# Altium schematic sheet main.SchDoc
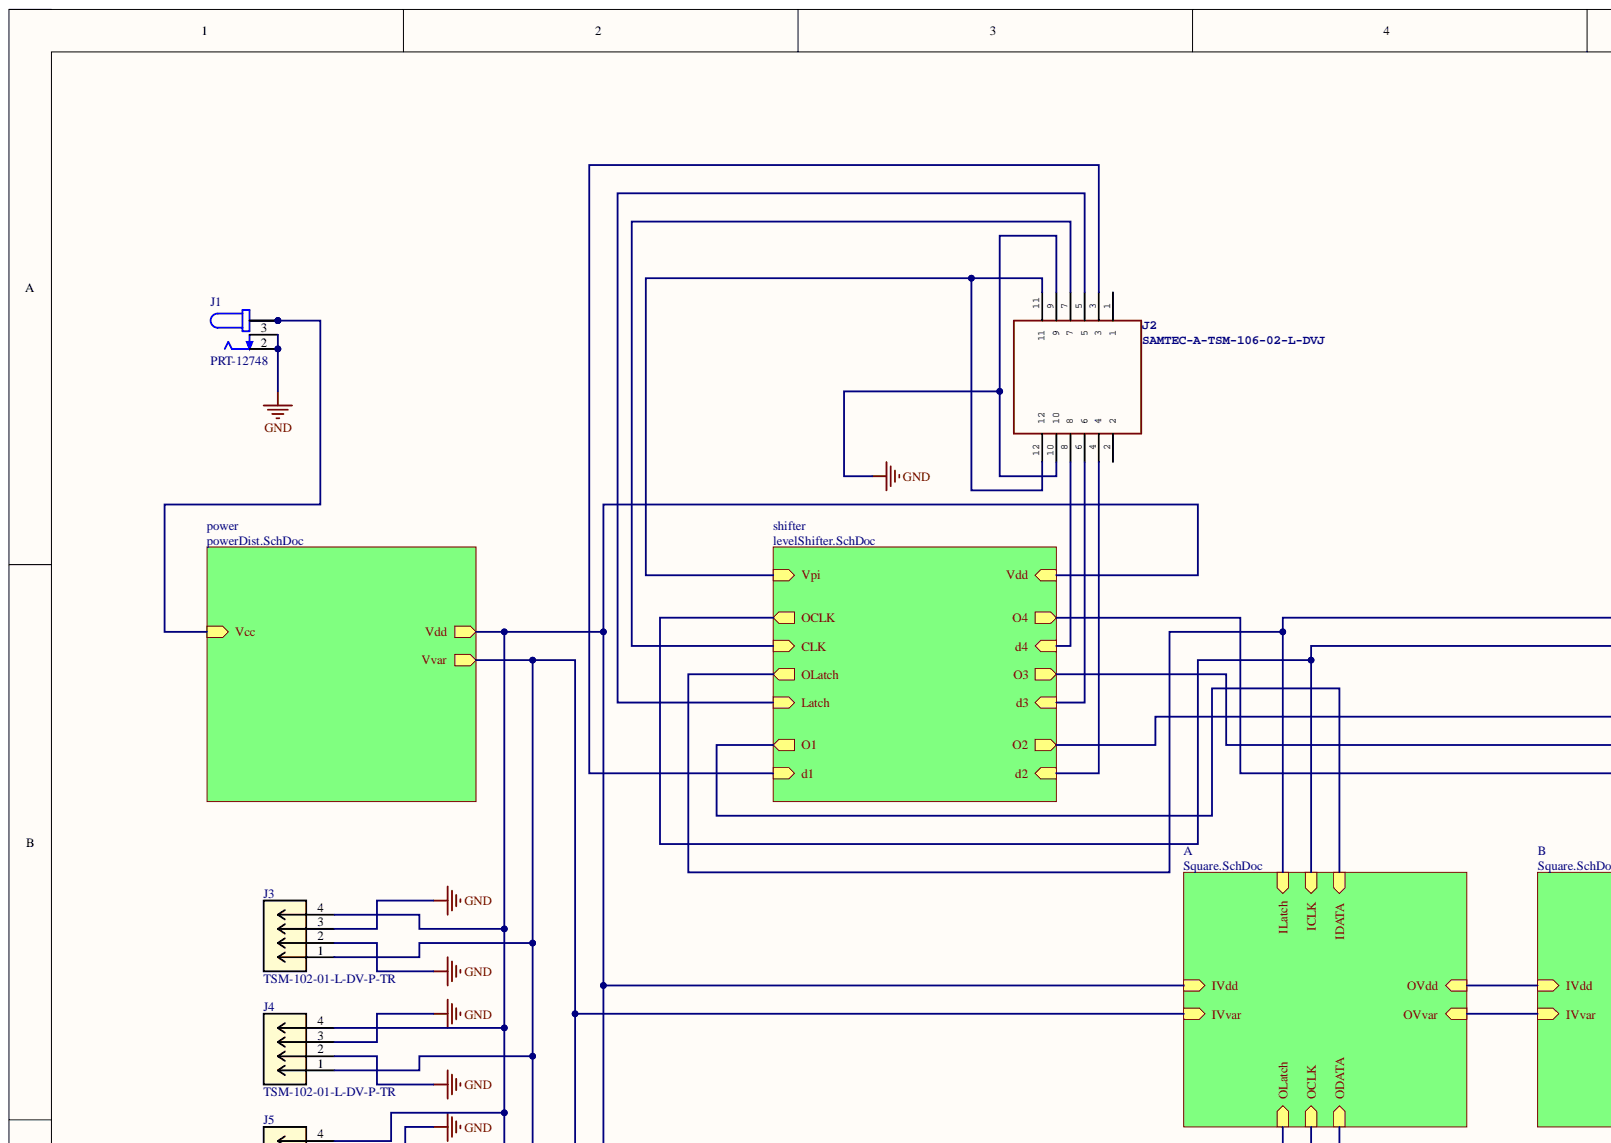
[diagram p0: main.SchDoc, top left]
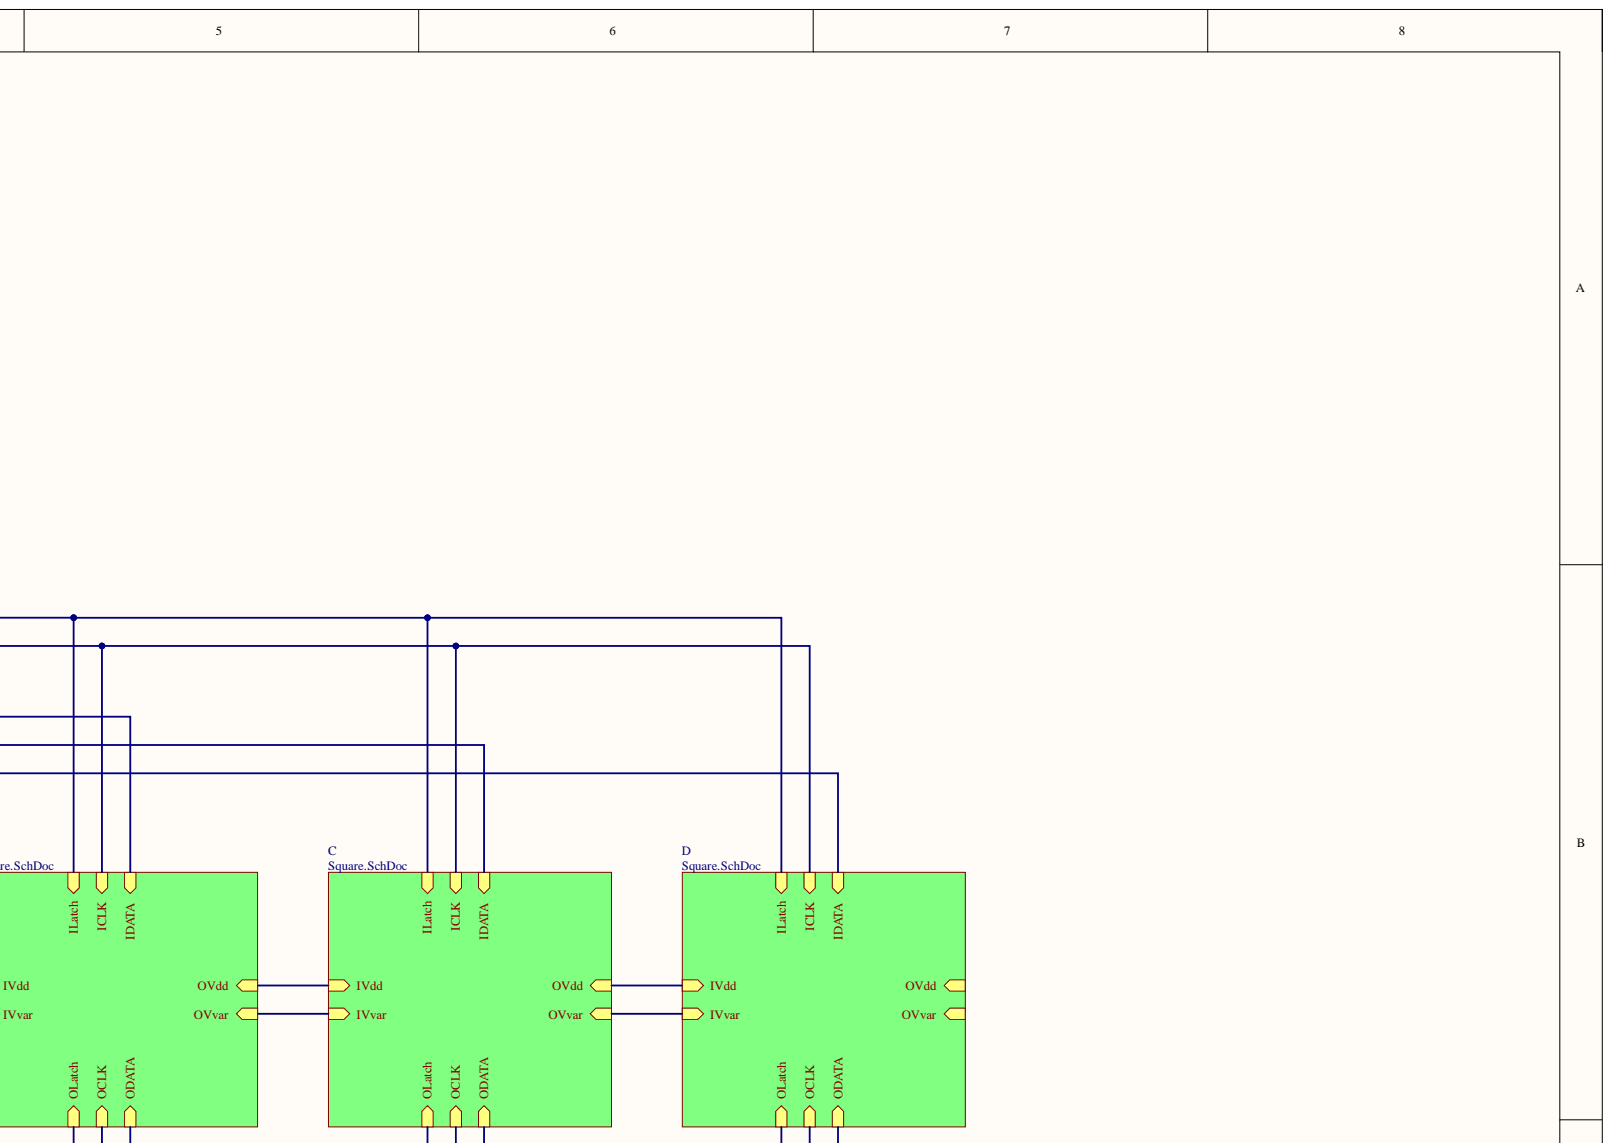
[diagram p1: main.SchDoc, top right]
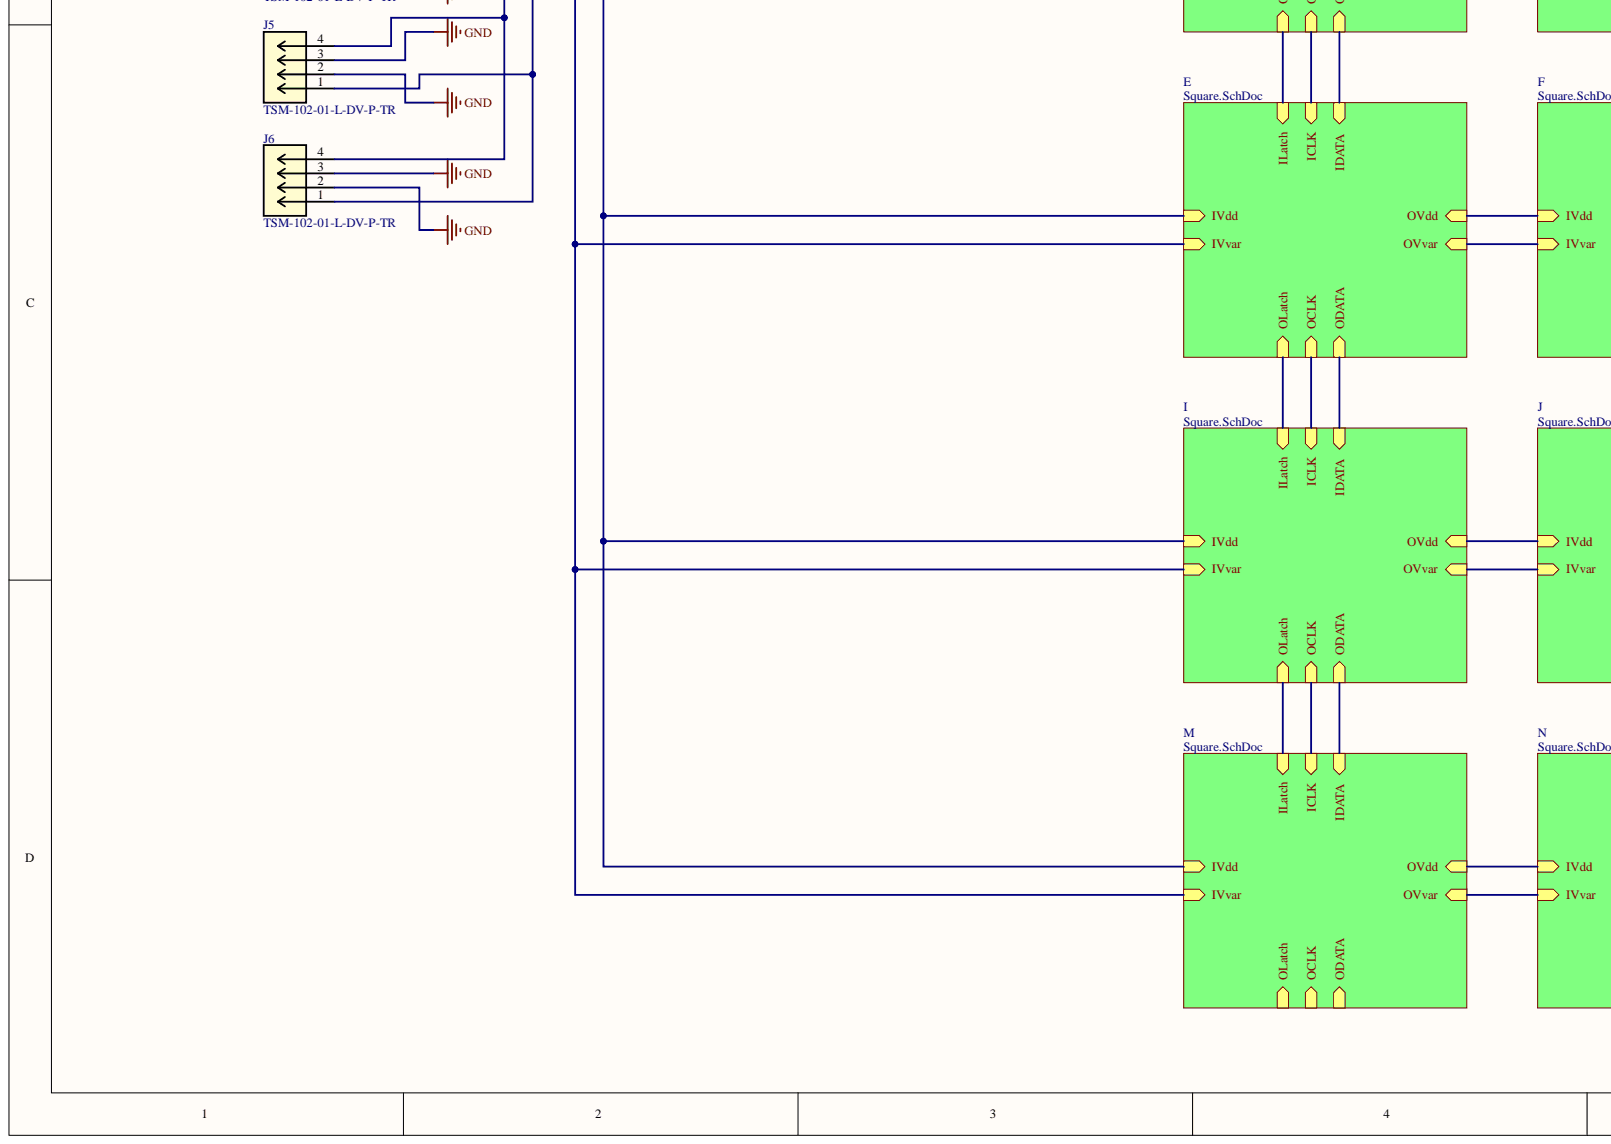
[diagram p2: main.SchDoc, bottom left]
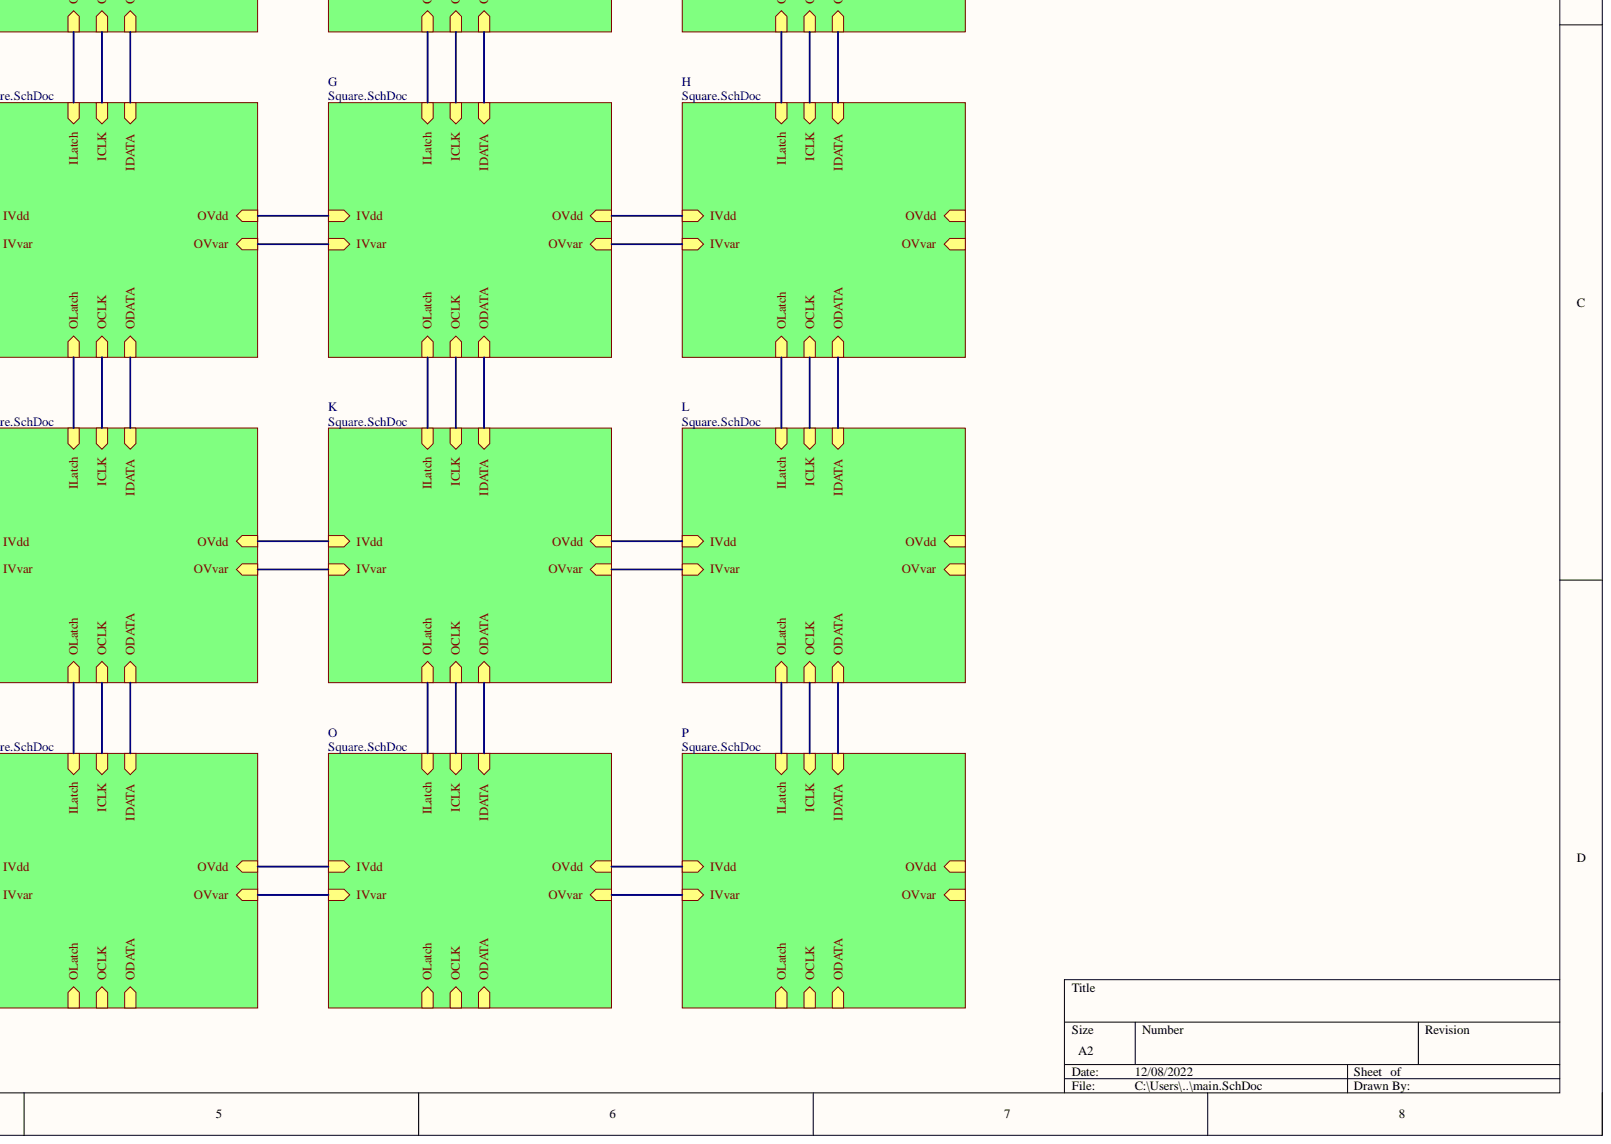
[diagram p3: main.SchDoc, bottom right]

## Parts
J1  PRT-12748  [SPARKFUN_PRT-12748]
J2  SAMTEC-A-TSM-106-02-L-DVJ  [SAMTEC-A-TSM-106-02-L-DV]
J3  TSM-102-01-L-DV-P-TR  [SAMTEC-A-TSM-102-02-L-DV]
J4  TSM-102-01-L-DV-P-TR  [SAMTEC-A-TSM-102-02-L-DV]
J5  TSM-102-01-L-DV-P-TR  [SAMTEC-A-TSM-102-02-L-DV]
J6  TSM-102-01-L-DV-P-TR  [SAMTEC-A-TSM-102-02-L-DV]

## Nets
GND: J1.2 J1.3 J2.9 J2.10 J3.2 J3.3 J4.2 J4.3 J5.2 J5.3 J6.2 J6.3
NetJ1_1_1: J1.1_1 J1.1_2
NetJ2_11: J2.11 J2.12
NetJ3_1: J3.1 J4.1 J5.1 J6.1
NetJ3_4: J3.4 J4.4 J5.4 J6.4
ICLK:
IDATA:
ILatch:
IVdd:
IVvar:
OCLK:
ODATA:
OLatch:
OVdd:
OVvar:

Not connected: J2 1 2 3 4 5 6 7 8

## Library data of the parts
J1 = PRT-12748: SparkFun Accessories DC Barrel Power Jack Connector SMD; Availability: In Stock; Check_prices: https://www.snapeda.com/parts/PRT-12748/SparkFun/view-part/?ref=eda; Component Kind: Standard; Library Name: PRT-12748.IntLib; MF: SparkFun; MP: PRT-12748; Package: None; Price: None; Purchase-URL: https://pricing.snapeda.com/search/part/PRT-12748/?ref=eda; SnapEDA_Link: https://www.snapeda.com/parts/PRT-12748/SparkFun/view-part/?ref=snap
J3-J6 = TSM-102-01-L-DV-P-TR: No Description Available; Mfr_Name: Samtec Inc; Component Kind: Standard; Library Name: TSM-102-01-L-DV-P-TR.SchLib; Manufacturer_Part_Number: TSM-102-01-L-DV-P-TR; RefDes: RefDes; Type: DEV; Copyright: Copyright (C) 2022 Ultra Librarian. All rights reserved.
J2 = SAMTEC-A-TSM-106-02-L-DVJ: Component Kind: Standard; Library Name: SAMTEC-A-TSM-106-02-L-DV.IntLib
